# Altium schematic sheet iobrd.SchDoc
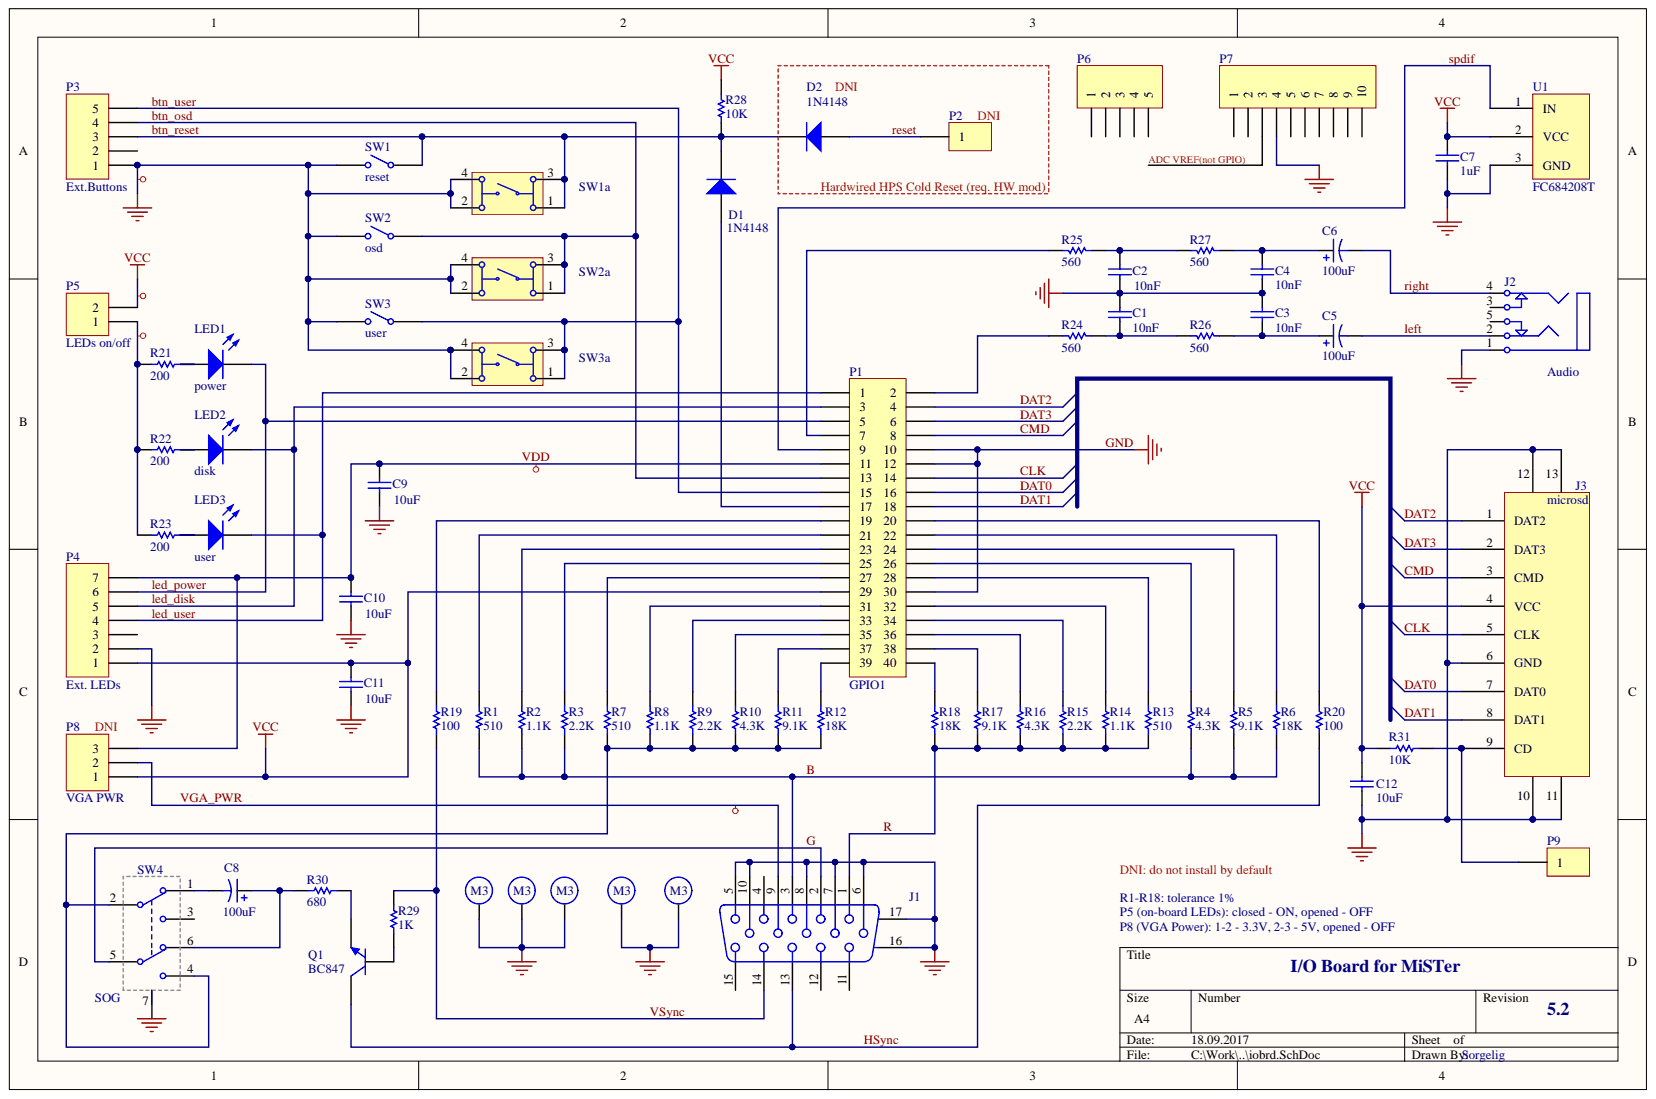
[diagram p0: iobrd.SchDoc]

## Parts
C1  value 10nF  [C0805]  (hidden comment: C0805)
C2  value 10nF  [C0805]  (hidden comment: C0805)
C3  value 10nF  [C0805]  (hidden comment: C0805)
C4  value 10nF  [C0805]  (hidden comment: C0805)
C5  value 100uF  [CAPT]  (hidden comment: T520D)
C6  value 100uF  [CAPT]  (hidden comment: T520D)
C7  value 1uF  [C0805]  (hidden comment: C0805)
C8  value 100uF  [CAPT]  (hidden comment: T520D)
C9  value 10uF  [C0805]  (hidden comment: C0805)
C10  value 10uF  [C0805]  (hidden comment: C0805)
C11  value 10uF  [C0805]  (hidden comment: C0805)
C12  value 10uF  [C0805]  (hidden comment: C0805)
D1  Part number: 1N4148  [DIODE]  (hidden comment: BAV100)
D2  Partnumber: 1N4148  [DIODE]  (hidden comment: BAV100)
H1  (no value)  [M3]
H2  (no value)  [M3]
H3  (no value)  [M3]
H4  (no value)  [M3]
H5  (no value)  [M3]
J1  vga  [VGA]  (value not drawn)
J2  Audio  [phonejack]
J3  microsd  [microsd]
LED1  power  [LEDR]
LED2  disk  [LEDW]
LED3  user  [LEDY]
P1  GPIO1  [HDR20x2]
P2  Header 1  [Header 1]  (value not drawn)
P3  Ext.Buttons  [HDR5]
P4  Ext. LEDs  [HDR7]
P5  LEDs on/off  [HDR2]
P6  Header 5  [HDR1X5]  (value not drawn)
P7  Header 10  [HDR1X10]  (value not drawn)
P8  VGA PWR  [HDR1X3]
P9  Header 1  [Header 1]  (value not drawn)
Q1  BC847  [SOT-23B_N]
R1  510  [R0805]
R2  1.1K  [R0805]
R3  2.2K  [R0805]
R4  4.3K  [R0805]
R5  9.1K  [R0805]
R6  18K  [R0805]
R7  510  [R0805]
R8  1.1K  [R0805]
R9  2.2K  [R0805]
R10  4.3K  [R0805]
R11  9.1K  [R0805]
R12  18K  [R0805]
R13  510  [R0805]
R14  1.1K  [R0805]
R15  2.2K  [R0805]
R16  4.3K  [R0805]
R17  9.1K  [R0805]
R18  18K  [R0805]
R19  100  [R0805]
R20  100  [R0805]
R21  200  [R0805]
R22  200  [R0805]
R23  200  [R0805]
R24  560  [R0805]
R25  560  [R0805]
R26  560  [R0805]
R27  560  [R0805]
R28  10K  [R0805]
R29  1K  [R0805]
R30  680  [R0805]
R31  10K  [R0805]
SW1  reset  [TACT_60X35]
SW1a  (no value)  [tact 12x12]
SW2  osd  [TACT_60X35]
SW2a  (no value)  [tact 12x12]
SW3  user  [TACT_60X35]
SW3a  (no value)  [tact 12x12]
SW4  SOG  [SW DPDT]
U1  FC684208T  [TOSLINK]

## Nets
VCC: C7.2 C11.2 C12.2 J3.4(VCC) P1.29 P4.1 P5.2 P8.1 R28.2 R31.2 U1.2
B: J1.3 R1.1 R2.1 R3.1 R4.1 R5.1 R6.1
btn_osd: P1.13 P3.4 SW2.1 SW2a.1 SW2a.3
btn_reset: D1.2 D2.2 P3.3 R28.1 SW1.1 SW1a.1 SW1a.3
btn_user: P1.15 P3.5 SW3.1 SW3a.1 SW3a.3
CLK: J3.5(CLK) P1.14
CMD: J3.3(CMD) P1.8
DAT0: J3.7(DAT0) P1.16
DAT1: J3.8(DAT1) P1.18
DAT2: J3.1(DAT2) P1.4
DAT3: J3.2(DAT3) P1.6
G: J1.2 SW4.5
GND: C1.1 C2.1 C3.1 C4.1 C7.1 C9.1 C10.1 C11.1 C12.1 H1.1 H2.1 H3.1 H4.1 H5.1 J1.5 J1.6 J1.7 J1.8 J1.10 J1.16 J1.17 J2.1 J3.6(GND) J3.10 J3.11 J3.12 J3.13 P1.10 P1.12 P1.30 P3.1 P4.2 P7.4 SW1.2 SW1a.2 SW1a.4 SW2.2 SW2a.2 SW2a.4 SW3.2 SW3a.2 SW3a.4 SW4.7 U1.0 U1.3
HSync: J1.13 Q1.3 R20.1
led_disk: LED2.1 P1.3 P4.5
led_power: LED1.1 P1.5 P4.6
led_user: LED3.1 P1.1 P4.4
left: C5.2 J2.2
R: J1.1 R13.2 R14.2 R15.2 R16.2 R17.2 R18.2
reset: D2.1 P2.1
right: C6.2 J2.4
spdif: P1.9 U1.1
VDD: C9.2 C10.2 P1.11 P4.7 P8.3
VGA_PWR: J1.9 P8.2
VSync: J1.14 R19.1 R29.1
NetC1_2: C1.2 R24.2 R26.1
NetC2_2: C2.2 R25.2 R27.1
NetC3_2: C3.2 C5.1 R26.2
NetC4_2: C4.2 C6.1 R27.2
NetC8_1: C8.1 R30.1 SW4.6
NetC8_2: C8.2 SW4.1
NetD1_1: D1.1 P1.17
NetJ3_9: J3.9(CD) P9.1 R31.1
NetLED1_2: LED1.2 R21.2
NetLED2_2: LED2.2 R22.2
NetLED3_2: LED3.2 R23.2
NetP1_2: P1.2 R24.1
NetP1_7: P1.7 R25.1
NetP1_19: P1.19 R19.2
NetP1_20: P1.20 R20.2
NetP1_21: P1.21 R1.2
NetP1_22: P1.22 R6.2
NetP1_23: P1.23 R2.2
NetP1_24: P1.24 R5.2
NetP1_25: P1.25 R3.2
NetP1_26: P1.26 R4.2
NetP1_27: P1.27 R7.2
NetP1_28: P1.28 R13.1
NetP1_31: P1.31 R8.2
NetP1_32: P1.32 R14.1
NetP1_33: P1.33 R9.2
NetP1_34: P1.34 R15.1
NetP1_35: P1.35 R10.2
NetP1_36: P1.36 R16.1
NetP1_37: P1.37 R11.2
NetP1_38: P1.38 R17.1
NetP1_39: P1.39 R12.2
NetP1_40: P1.40 R18.1
NetP5_1: P5.1 R21.1 R22.1 R23.1
NetQ1_1: Q1.1 R29.2
NetQ1_2: Q1.2 R30.2
NetR7_1: R7.1 R8.1 R9.1 R10.1 R11.1 R12.1 SW4.2 SW4.4
N00018:

Not connected: J1 4 11 12 15; J2 3 5; P3 2; P4 3; P6 1 2 3 4 5; P7 1 2 3 5 6 7 8 9 10; SW4 3

## Library data of the parts
C1-C4 C7 C9-C12 = C0805: Ceramic Chip Capacitor - Standard; Note: Footprint corresponds to dimensions for bulk cassette packaging. This case size has tightened tolerances.; Code_IPC: 2012[0805]; Code_JEITA: 0805; Component Kind: Standard; ComponentLink1Description: Manufacturer Link; ComponentLink1URL: http://www.kemet.com/; ComponentLink2Description: Datasheet; ComponentLink2URL: http://www.kemet.com/kemet/web/homepage/kechome.nsf/vapubfilesname/F3102TA.pdf/$file/F3102TA.pdf; DatasheetDocument: Mar-2001; LatestRevisionDate: 19-Mar-2004; LatestRevisionNote: Silkscreen size reduced.; Library Name: lib.PcbLib; PackageDocument: Mar-2001; PackageReference: 0805; Published: 17-Jul-2002; Signal Integrity: C0805; Simulation: CAP; Publisher: Altium Limited
C5 C6 C8 = T520D: Polymer Tantalum Chip Capacitor, T520 Series - KO-CAP Polymer; Code_JEITA: 7343-31; Component Kind: Standard; ComponentLink1Description: Manufacturer Link; ComponentLink1URL: http://www.kemet.com/; ComponentLink2Description: Datasheet; ComponentLink2URL: http://www.kemet.com/kemet/web/homepage/kechome.nsf/vapubfilesname/F3102TA.pdf/$file/F3102TA.pdf; DatasheetDocument: Mar-2001; LatestRevisionDate: 19-Mar-2004; LatestRevisionNote: Silkscreen dimensions re-sized.; Library Name: lib.PcbLib; PackageDocument: Mar-2001; PackageReference: D; Published: 17-Jul-2002; Signal Integrity: T520D; Simulation: CAP; Publisher: Altium Limited
D1 D2 = BAV100: General-purpose Diode; Component Kind: Standard; ComponentLink1Description: Manufacturer Link; ComponentLink1URL: http://www.semiconductors.philips.com/; ComponentLink2Description: Datasheet; ComponentLink2URL: http://www.semiconductors.philips.com/acrobat/datasheets/BAV100_2.pdf; DatasheetVersion: 17-Sep-1996; LatestRevisionDate: 19-Mar-2004; LatestRevisionNote: Silkscreen size reduced.; Library Name: Philips Discrete Diode - Switching.IntLib; PackageDescription: Metal Electrode Face (MELF) Diode; Body 3.5 x 1.6 mm (LxDia); PackageReference: SOD80C; PackageVersion: Jan-2002; Published: 17-Sep-2003; Signal Integrity: BAV100; Simulation: BAV103; Publisher: Altium Limited
H1-H5 = M3: Component Kind: Standard; Library Name: lib.SchLib
J2 = phonejack: Component Kind: Standard; Library Name: lib.SchLib
LED1-LED3 = 151031SS04000: THT LED round mono-color color lens, WL-TMRW, Super Red; Component Kind: Standard; ComponentLink1Description: Manufacturer URL; ComponentLink1URL: http://www.we-online.com; ComponentLink2Description: Datasheet; ComponentLink2URL: http://katalog.we-online.de/pbs/datasheet/7448042001.pdfhttp://katalog.we-online.de/pbs/datasheet/7448042001.pdfhttp://katalog.we-online.de/pbs/datasheet/7448042001.pdfhttp://katalog.we-online.de/pbs/datasheet/151031SS04000.pdf; Emitting color: Super Red; PackageReference: Type 3mm; PartNumber: 151031SS04000; Library Name: WL-TMRC THT LED round mono-color color lens.IntLib
P1 = Header 20X2: Header, 20-Pin, Dual row; Component Kind: Standard; LatestRevisionDate: 17-Jul-2002; LatestRevisionNote: Re-released for DXP Platform.; Library Name: Miscellaneous Connectors.IntLib; Signal Integrity: Connector; Publisher: Altium Limited
P3 P6 = Header 5: Header, 5-Pin; Component Kind: Standard; LatestRevisionDate: 17-Jul-2002; LatestRevisionNote: Re-released for DXP Platform.; Library Name: Miscellaneous Connectors.IntLib; Signal Integrity: Connector; Publisher: Altium Limited
P4 = Header 7: Header, 7-Pin; Component Kind: Standard; LatestRevisionDate: 17-Jul-2002; LatestRevisionNote: Re-released for DXP Platform.; Library Name: Miscellaneous Connectors.IntLib; Signal Integrity: Connector; Publisher: Altium Limited
P5 = Header 2: Header, 2-Pin; Component Kind: Standard; LatestRevisionDate: 17-Jul-2002; LatestRevisionNote: Re-released for DXP Platform.; Library Name: Miscellaneous Connectors.IntLib; Signal Integrity: Connector; Publisher: Altium Limited
P7 = Header 10: Header, 10-Pin; Component Kind: Standard; LatestRevisionDate: 17-Jul-2002; LatestRevisionNote: Re-released for DXP Platform.; Library Name: Miscellaneous Connectors.IntLib; Signal Integrity: Connector; Publisher: Altium Limited
P8 = Header 3: Header, 3-Pin; Component Kind: Standard; LatestRevisionDate: 17-Jul-2002; LatestRevisionNote: Re-released for DXP Platform.; Library Name: Miscellaneous Connectors.IntLib; Signal Integrity: Connector; Publisher: Altium Limited
Q1 = QNPN: NPN Bipolar Transistor; Component Kind: Standard; LatestRevisionDate: 29-May-2009; LatestRevisionNote: IPC-7351 Footprint Added.; Library Name: Miscellaneous Devices.IntLib; PackageDescription: 3-Pin SOT-23 Package 0.95 mm Pitch, 2.4 mm Lead Span; PackageReference: SOT-23B; PackageVersion: Aug-1999; Published: 8-Jun-2000; Signal Integrity: NPN; Simulation: QNPN; Publisher: Altium Limited
R1-R31 = ERJ6RS: Low Ohmic Value Thick Film Chip Resistor, 0.1 Ohm to 0.2 Ohm Range, 1%, 2% and 5% Tolerance, 0805 Size, 0.125 W; Code_IPC: 2012 [0805]; Component Kind: Standard; ComponentLink1Description: Manufacturer Link; ComponentLink1URL: http://www.panasonic.com/industrial/components/resistive_products.htm; ComponentLink2Description: Datasheet; ComponentLink2URL: http://www.panasonic.com/industrial/components/pdf/AOA0000CE3.pdf; LatestRevisionDate: 19-Mar-2004; LatestRevisionNote: Silkscreen size reduced.; Library Name: lib.PcbLib; PackageDocument: Mar-2003; PackageReference: 6-0805; Published: 17-Dec-2003; Signal Integrity: Res; Simulation: RESISTOR; Publisher: Altium Limited
SW1-SW3 = Tact_60X35: Tact 6.0mm X 3.5mm; PartNumber: 434111050826; NoPins: 02; Component Kind: Standard; ComponentLink1Description: Manufacturer URL; ComponentLink1URL: http://www.we-online.com; ComponentLink2Description: Datasheet; ComponentLink2URL: http://katalog.we-online.de/em/datasheet/434111050826.pdf; Library Name: lib.SchLib; PackageReference: 434111050826
SW1a SW2a SW3a = tact 12x12: Component Kind: Standard; Library Name: Schlib1.SchLib
SW4 = SW DPDT: Component Kind: Standard; Library Name: lib.SchLib
U1 = Toslink: Component Kind: Standard; Description: FC684208T; Library Name: lib.SchLib
J1 = vga: Component Kind: Standard; Library Name: lib.SchLib
J3 = microsd: Component Kind: Standard; Library Name: lib.SchLib
P2 P9 = Header 1: Component Kind: Standard; Library Name: lib.SchLib
